# Altium schematic sheet Schematic3_.SchDoc(Schematic3_)
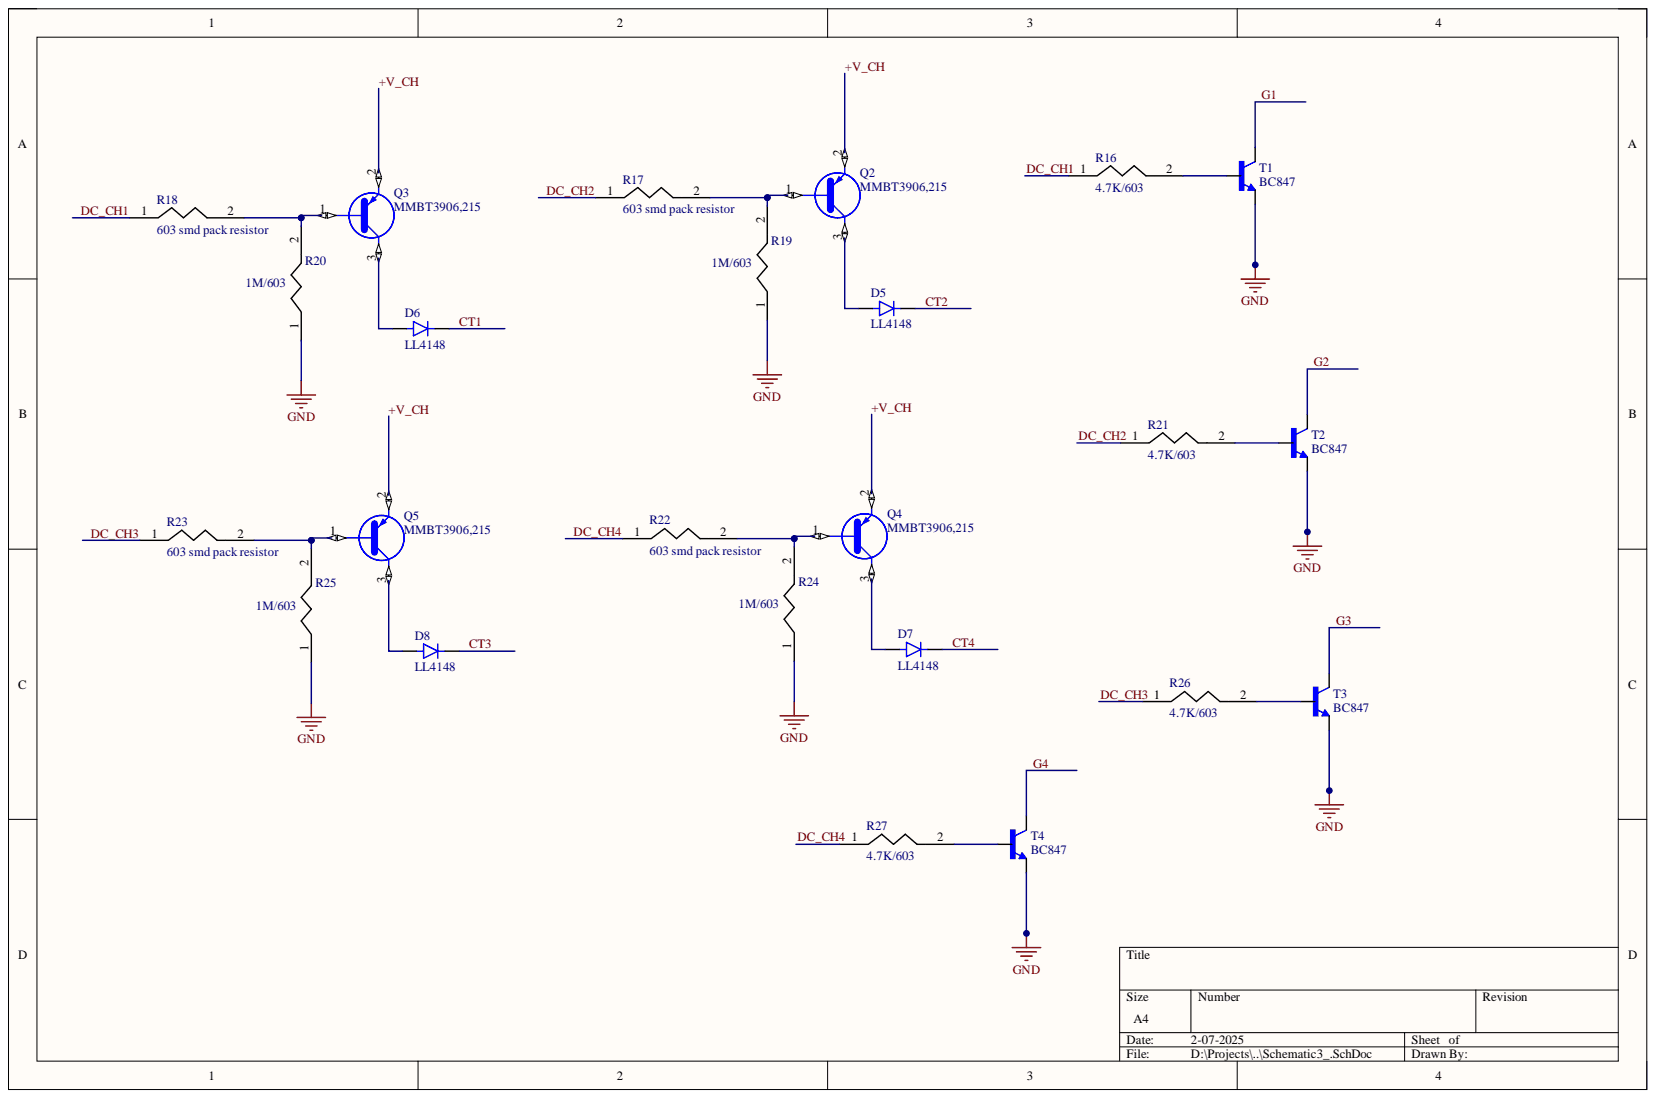
[diagram p0: Schematic3_.SchDoc]

## Parts
D5  LL4148  [DIO_LL4148]
D6  LL4148  [DIO_LL4148]
D7  LL4148  [DIO_LL4148]
D8  LL4148  [DIO_LL4148]
Q2  MMBT3906,215  [SOT95P230X110-3N]
Q3  MMBT3906,215  [SOT95P230X110-3N]
Q4  MMBT3906,215  [SOT95P230X110-3N]
Q5  MMBT3906,215  [SOT95P230X110-3N]
R16  4.7K/603  [603]
R17  603 smd pack resistor  [603]
R18  603 smd pack resistor  [603]
R19  1M/603  [603]
R20  1M/603  [603]
R21  4.7K/603  [603]
R22  603 smd pack resistor  [603]
R23  603 smd pack resistor  [603]
R24  1M/603  [603]
R25  1M/603  [603]
R26  4.7K/603  [603]
R27  4.7K/603  [603]
T1  BC847  [SOT23]
T2  BC847  [SOT23]
T3  BC847  [SOT23]
T4  BC847  [SOT23]

## Nets
GND: R19.1 R20.1 R24.1 R25.1 T1.2 T2.2 T3.2 T4.2
+V_CH: Q2.2 Q3.2 Q4.2 Q5.2
CT1: D6.C
CT2: D5.C
CT3: D8.C
CT4: D7.C
DC_CH1: R16.1 R18.1
DC_CH2: R17.1 R21.1
DC_CH3: R23.1 R26.1
DC_CH4: R22.1 R27.1
G1: T1.3
G2: T2.3
G3: T3.3
G4: T4.3
NetD5_A: D5.A Q2.3
NetD6_A: D6.A Q3.3
NetD7_A: D7.A Q4.3
NetD8_A: D8.A Q5.3
NetQ2_1: Q2.1 R17.2 R19.2
NetQ3_1: Q3.1 R18.2 R20.2
NetQ4_1: Q4.1 R22.2 R24.2
NetQ5_1: Q5.1 R23.2 R25.2
NetR16_2: R16.2 T1.1
NetR21_2: R21.2 T2.1
NetR26_2: R26.2 T3.1
NetR27_2: R27.2 T4.1

## Library data of the parts
D5-D8 = LL4148: Diode Small Signal Switching 100V 0.15A Automotive 2-Pin Mini-MELF T/R; MF: Diotec Semiconductor; Availability: In Stock; Check_prices: https://www.snapeda.com/parts/LL4148/Diotec/view-part/?ref=eda; Component Kind: Standard; Library Name: LL4148.IntLib; MP: LL4148; Package: MINIMELF-2 Diotec; Price: None; Purchase-URL: https://pricing.snapeda.com/search/part/LL4148/?ref=eda; SnapEDA_Link: https://www.snapeda.com/parts/LL4148/Diotec/view-part/?ref=snap
Q2-Q5 = MMBT3906,215: Bipolar (BJT) Transistor PNP 40V 200mA 250MHz 250mW Surface Mount TO-236AB; MF: Nexperia USA; Check_prices: https://www.snapeda.com/parts/MMBT3906,215/Nexperia/view-part/2887388/?ref=eda; Component Kind: Standard; Digi-Key_Part_Number: 1727-4045-1-ND; Library Name: MMBT3906_215.IntLib; MP: MMBT3906,215; Package: SOT23-3 Nexperia USA Inc.; Purchase-URL: https://pricing.snapeda.com/search/part/MMBT3906,215/?ref=eda; SnapEDA_Link: https://www.snapeda.com/parts/MMBT3906,215/Nexperia/view-part/2887388/?ref=snap
R16-R27 = 603 Resistor: Component Kind: Standard; Library Name: Custom1.IntLib
T1-T4 = BC847: <b>NPN TRANSISTOR</b>; Availability: Unavailable; Component Kind: Standard; Library Name: BC847.IntLib; MP: BC847; Package: None; Price: None
